# Altium schematic sheet PCard.SchDoc
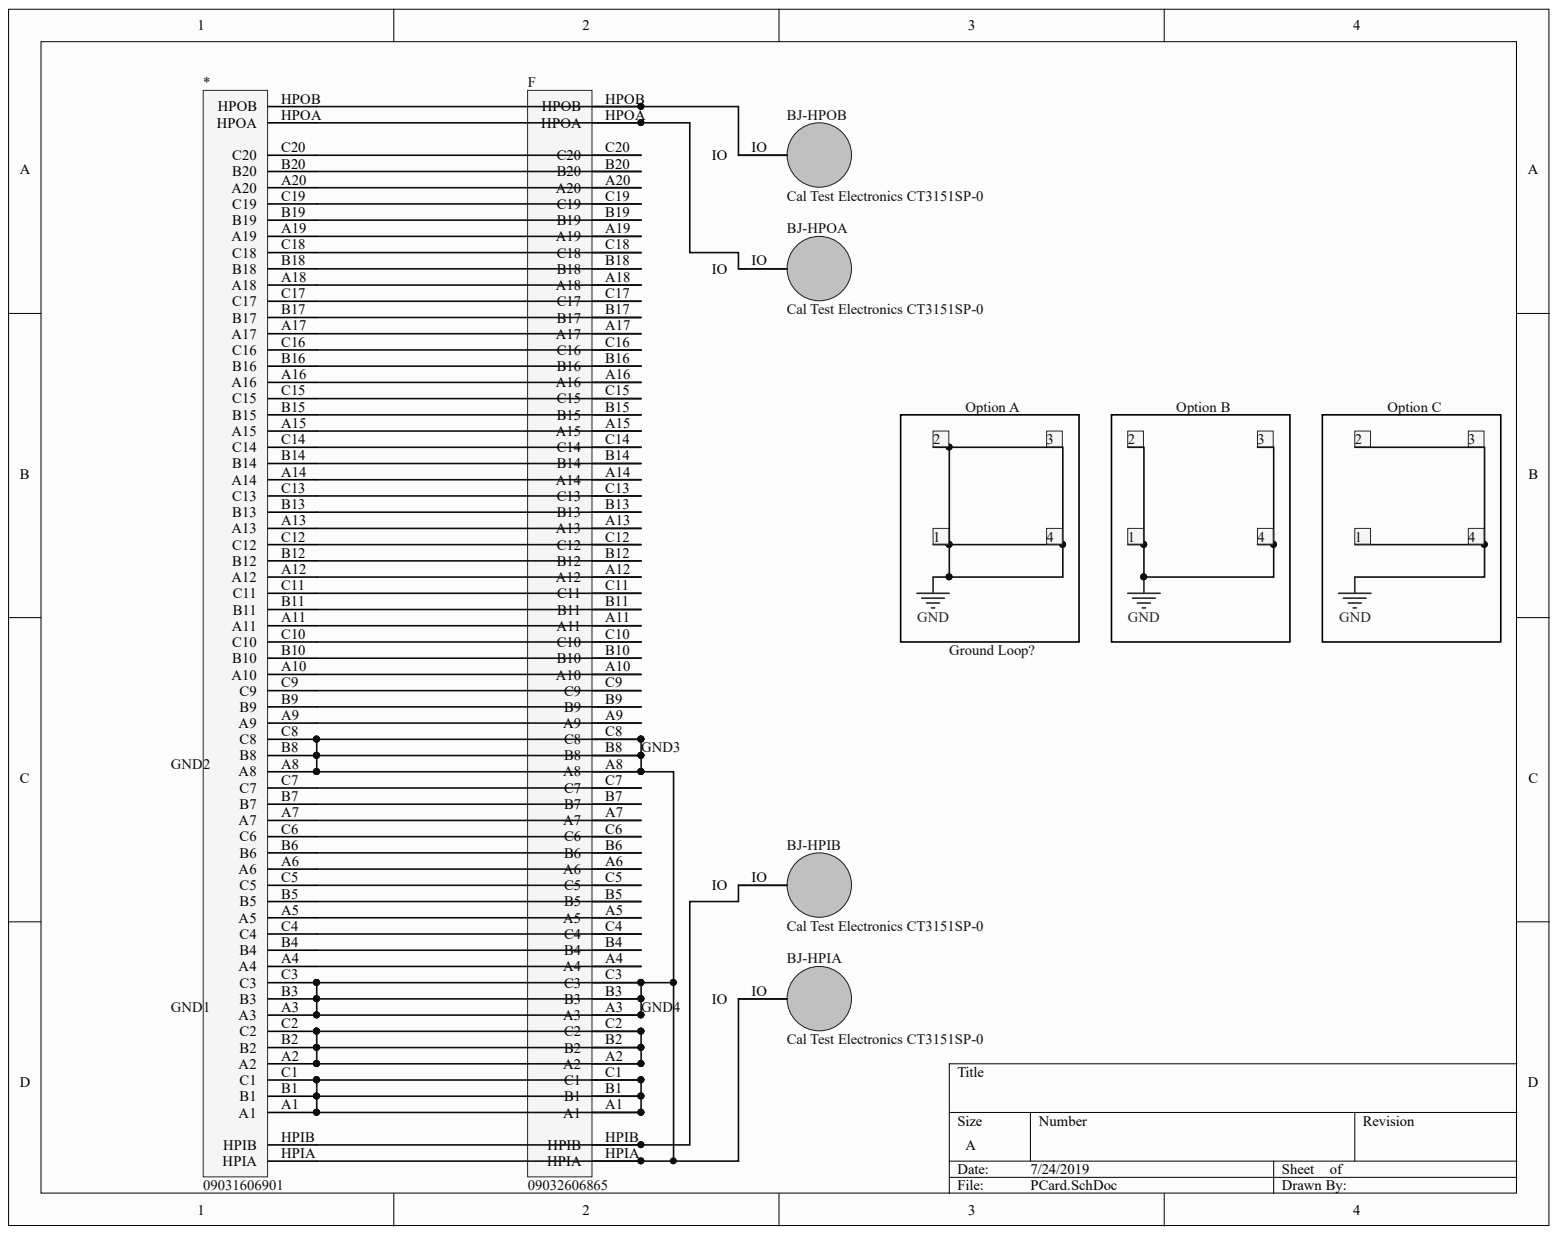
[diagram p0: PCard.SchDoc]

## Parts
*  09031606901  [PCB-uzhe8vs3bcqz6bzs18qy-1]
BJ-HPIA  Cal Test Electronics CT3151SP-0  [PCB-w5qi9w8z5aaxrmdn6hpp-1]
BJ-HPIB  Cal Test Electronics CT3151SP-0  [PCB-w5qi9w8z5aaxrmdn6hpp-1]
BJ-HPOA  Cal Test Electronics CT3151SP-0  [PCB-w5qi9w8z5aaxrmdn6hpp-1]
BJ-HPOB  Cal Test Electronics CT3151SP-0  [PCB-w5qi9w8z5aaxrmdn6hpp-1]
F  09032606865  [PCBComponent_1 - duplicate]

## Nets
Net*_A1: *.A1 *.B1 *.C1 F.A1 F.B1 F.C1
Net*_A2: *.A2 *.B2 *.C2 F.A2 F.B2 F.C2
Net*_A3: *.A3 *.A8 *.B3 *.B8 *.C3 *.C8 *.HPIA BJ-HPIA.IO F.A3 F.A8 F.B3 F.B8 F.C3 F.C8 F.HPIA
Net*_A4: *.A4 F.A4
Net*_A5: *.A5 F.A5
Net*_A6: *.A6 F.A6
Net*_A7: *.A7 F.A7
Net*_A9: *.A9 F.A9
Net*_A10: *.A10 F.A10
Net*_A11: *.A11 F.A11
Net*_A12: *.A12 F.A12
Net*_A13: *.A13 F.A13
Net*_A14: *.A14 F.A14
Net*_A15: *.A15 F.A15
Net*_A16: *.A16 F.A16
Net*_A17: *.A17 F.A17
Net*_A18: *.A18 F.A18
Net*_A19: *.A19 F.A19
Net*_A20: *.A20 F.A20
Net*_B4: *.B4 F.B4
Net*_B5: *.B5 F.B5
Net*_B6: *.B6 F.B6
Net*_B7: *.B7 F.B7
Net*_B9: *.B9 F.B9
Net*_B10: *.B10 F.B10
Net*_B11: *.B11 F.B11
Net*_B12: *.B12 F.B12
Net*_B13: *.B13 F.B13
Net*_B14: *.B14 F.B14
Net*_B15: *.B15 F.B15
Net*_B16: *.B16 F.B16
Net*_B17: *.B17 F.B17
Net*_B18: *.B18 F.B18
Net*_B19: *.B19 F.B19
Net*_B20: *.B20 F.B20
Net*_C4: *.C4 F.C4
Net*_C5: *.C5 F.C5
Net*_C6: *.C6 F.C6
Net*_C7: *.C7 F.C7
Net*_C9: *.C9 F.C9
Net*_C10: *.C10 F.C10
Net*_C11: *.C11 F.C11
Net*_C12: *.C12 F.C12
Net*_C13: *.C13 F.C13
Net*_C14: *.C14 F.C14
Net*_C15: *.C15 F.C15
Net*_C16: *.C16 F.C16
Net*_C17: *.C17 F.C17
Net*_C18: *.C18 F.C18
Net*_C19: *.C19 F.C19
Net*_C20: *.C20 F.C20
Net*_HPIB: *.HPIB BJ-HPIB.IO F.HPIB
Net*_HPOA: *.HPOA BJ-HPOA.IO F.HPOA
Net*_HPOB: *.HPOB BJ-HPOB.IO F.HPOB
GND:

## Library data of the parts
* = CMP-dd70146577e902b7-5: Conn DIN 41612 M 60Power/4Coaxial POS 2.54mm Solder RA Thru-Hole; Number of Mating Cycles: 400; Component Kind: Standard; Contact Plating: Gold over Nickel, Gold; Library Name: CommunityVault; Manufacturer Part Number: 09031606901; Mounting Style: Through Hole, PC Board, Solder; Octopart URL: https://octopart.com/09031606901-harting+elektronik-2068479; Packaging: Box; RoHS: Compliant
BJ-HPIA BJ-HPIB BJ-HPOA BJ-HPOB = CMP-869a4c9259de595d-1: 4MM Safety Jack , Horizontal Pcb Mount Black; Component Kind: Standard; Digikey Part Number: BKCT3151SP-0-ND; Library Name: CommunityVault; Manufacturer Part Number: CT3151SP-0; OctopartID: 869a4c9259de595d; Octopart URL: https://octopart.com/ct3151sp-0-cal+test+electronics-50526754
F = CMP-dgd04bf3j3drhl5uyyz7-11: CONN DIN RCPT 64POS PCB GOLD; Component Kind: Standard; CustomComponentID: dgd04bf3j3drhl5uyyz7; Library Name: CommunityVault
